# Altium schematic sheet KITT Combined LEDs.SchDoc("KITT Combined LEDs")
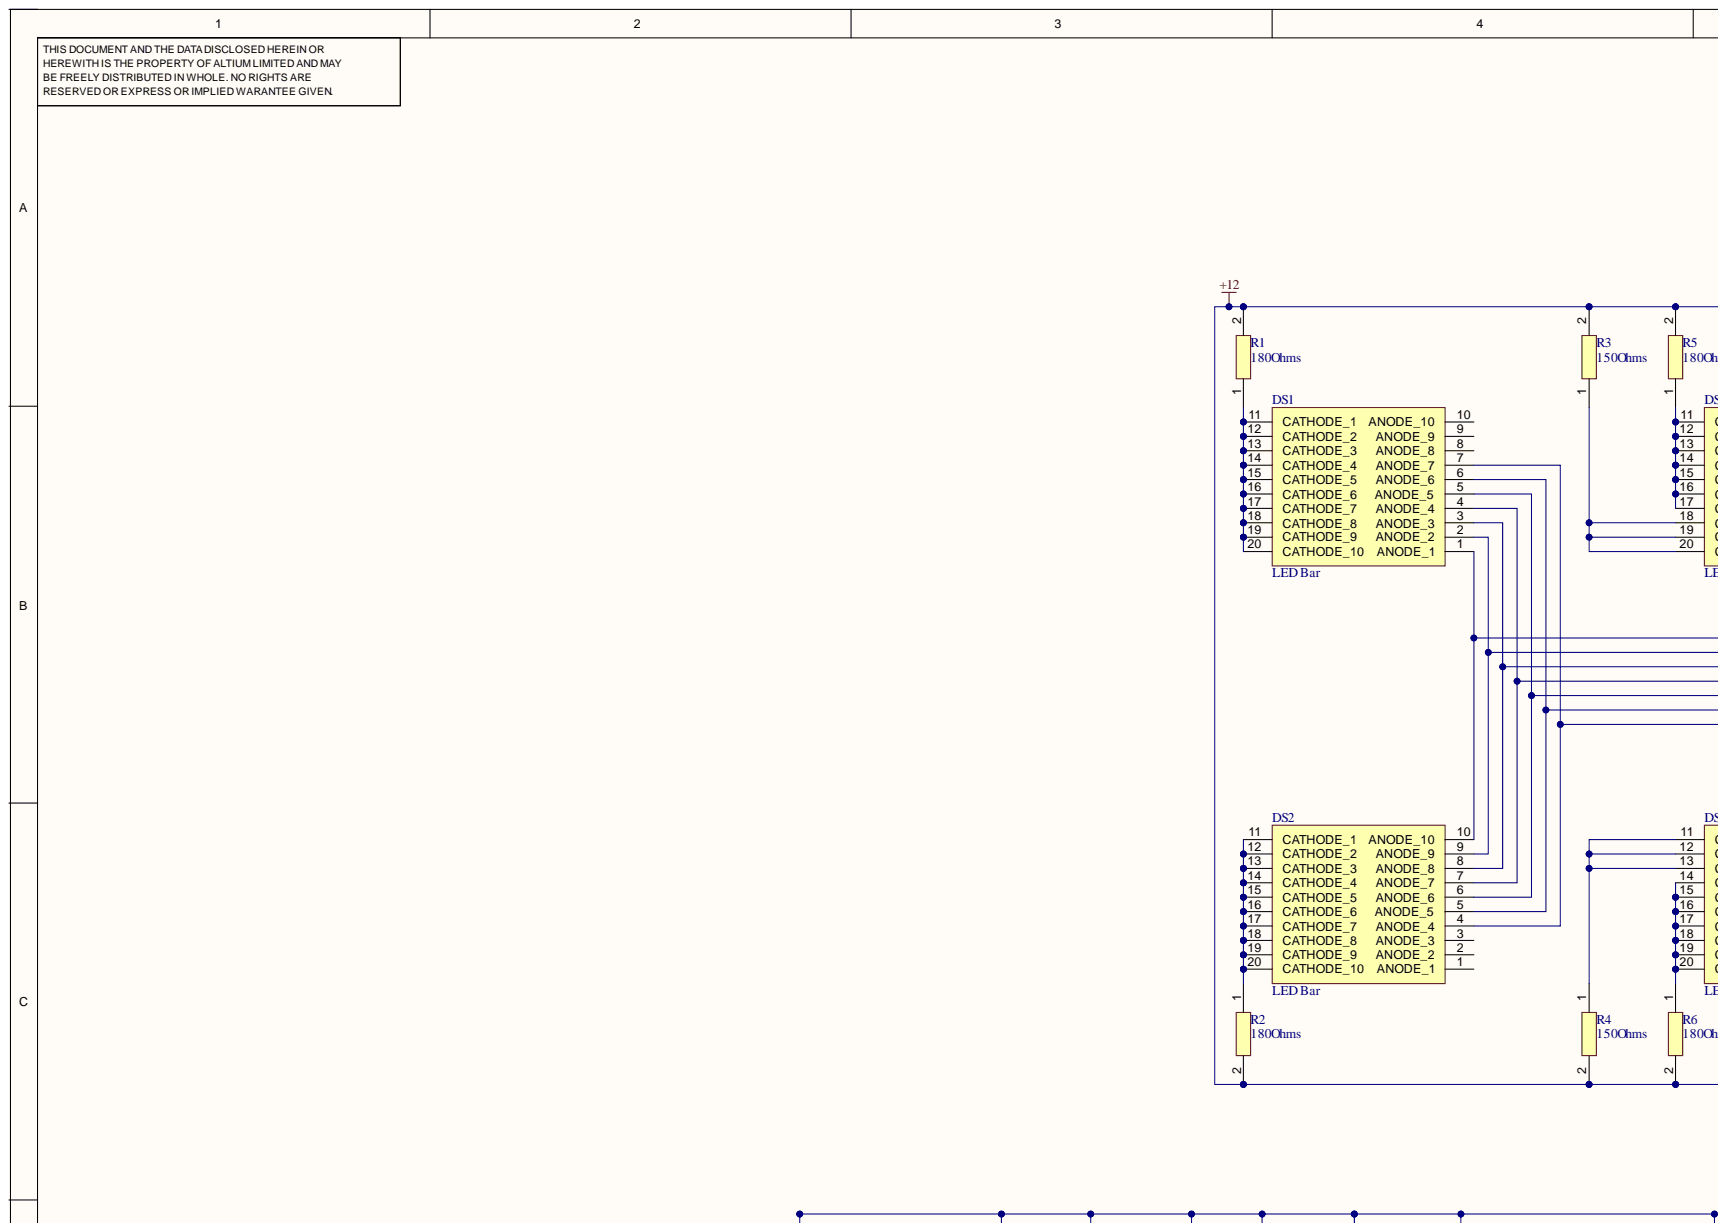
[diagram p0: KITT Combined LEDs.SchDoc, top left]
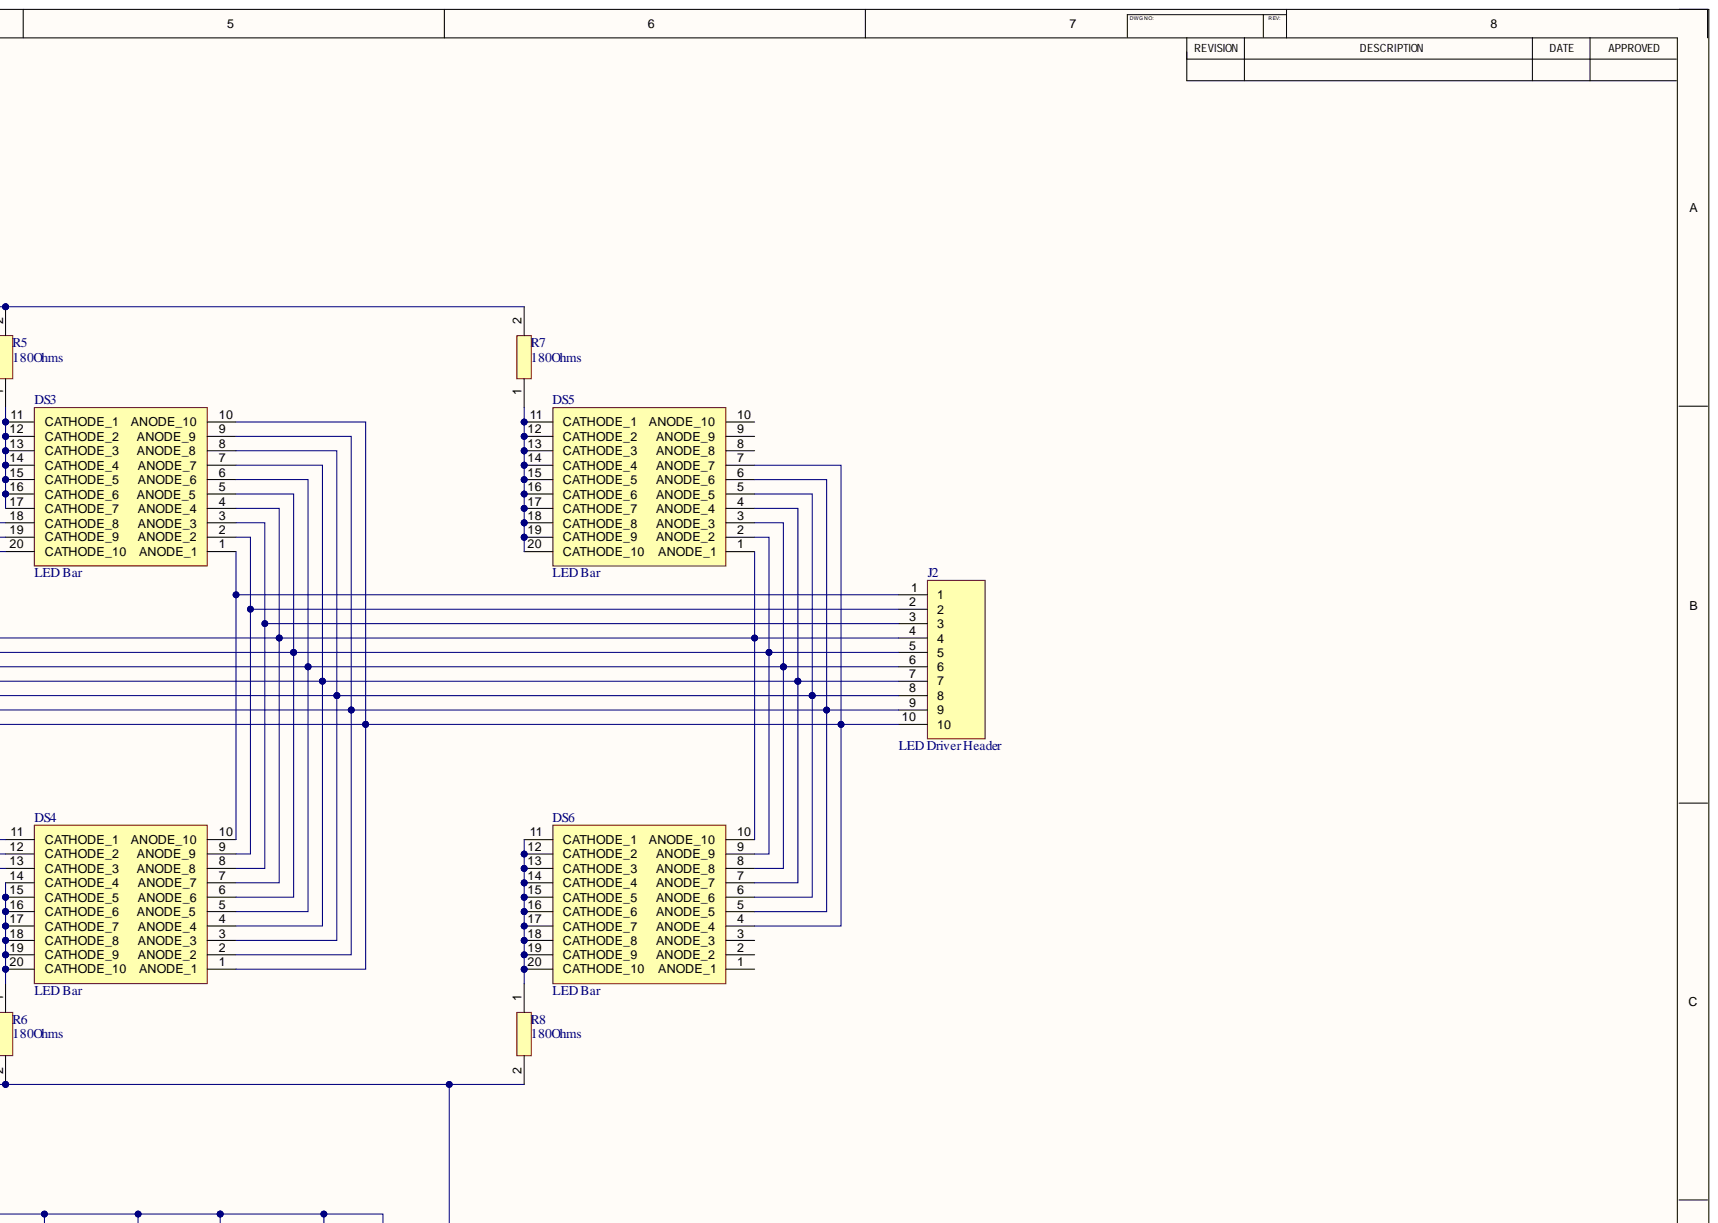
[diagram p1: KITT Combined LEDs.SchDoc, top right]
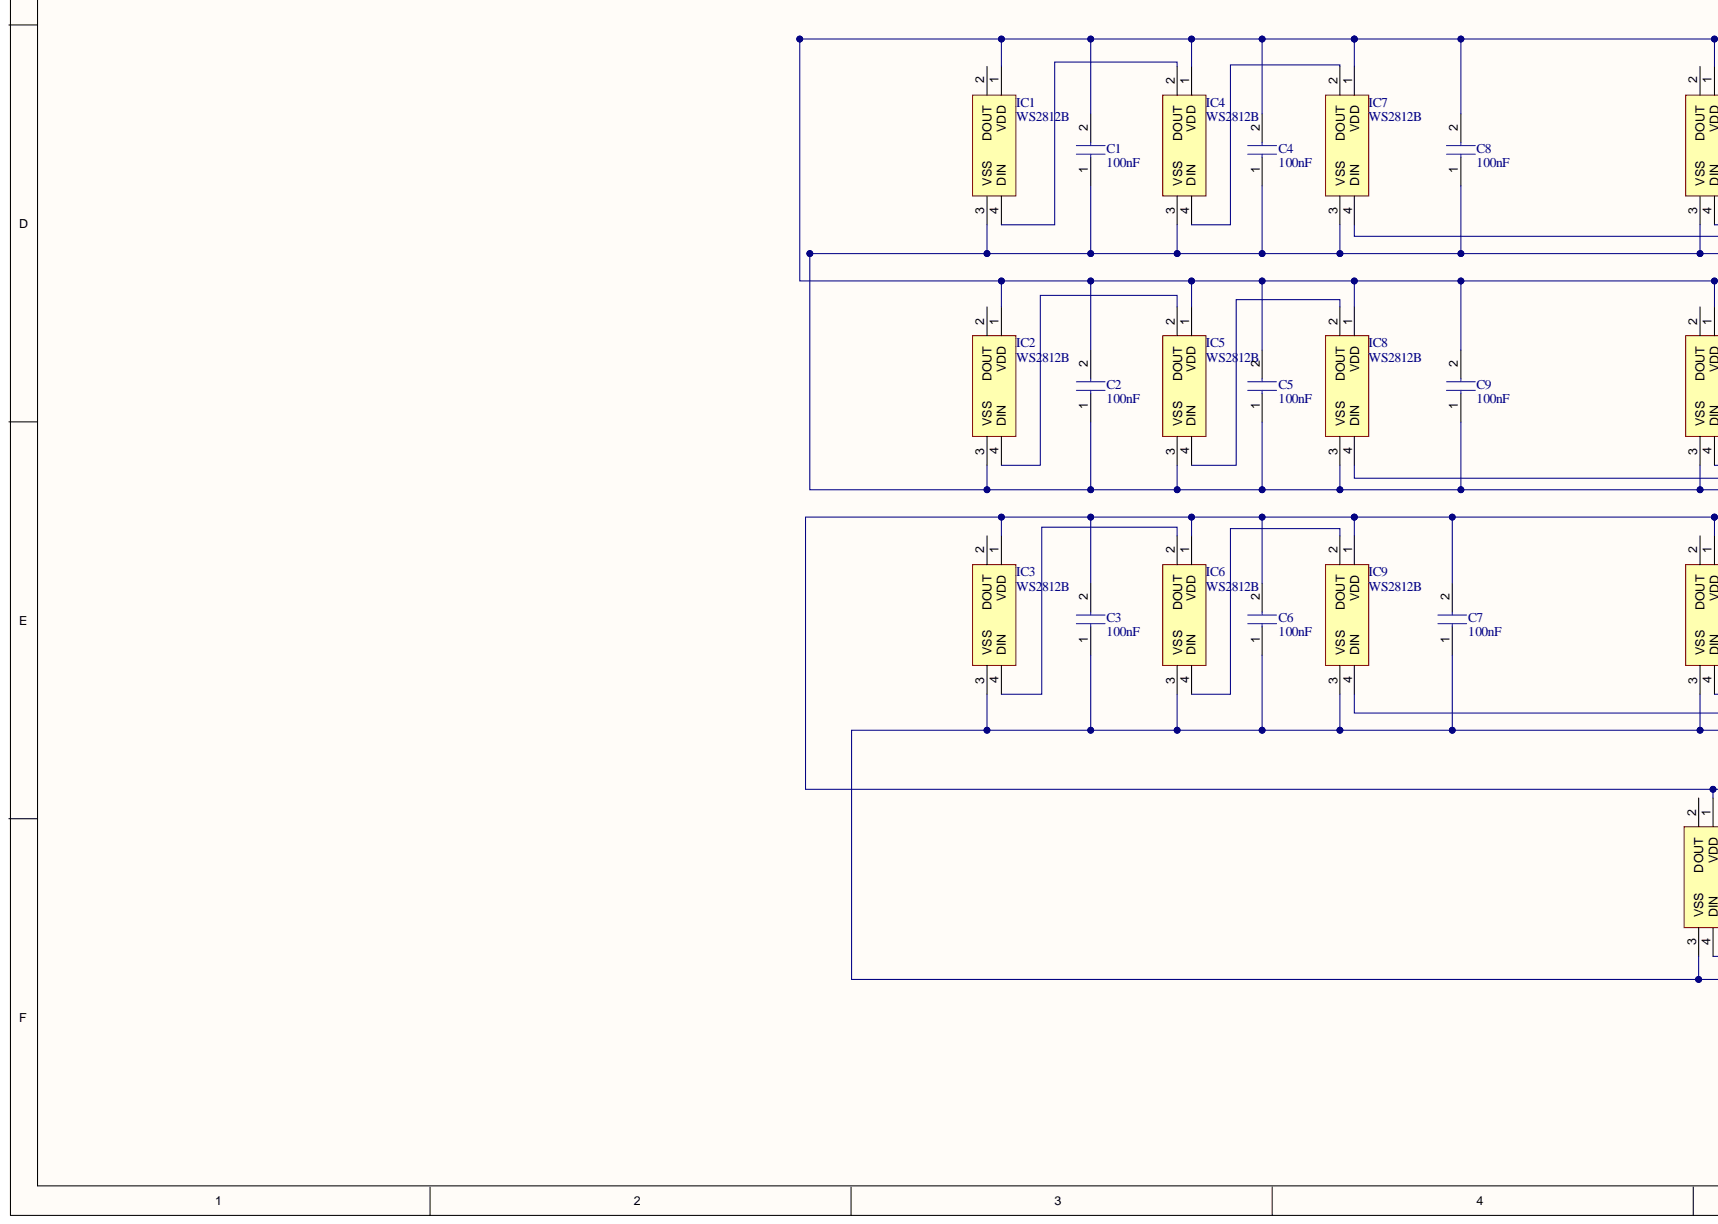
[diagram p2: KITT Combined LEDs.SchDoc, bottom left]
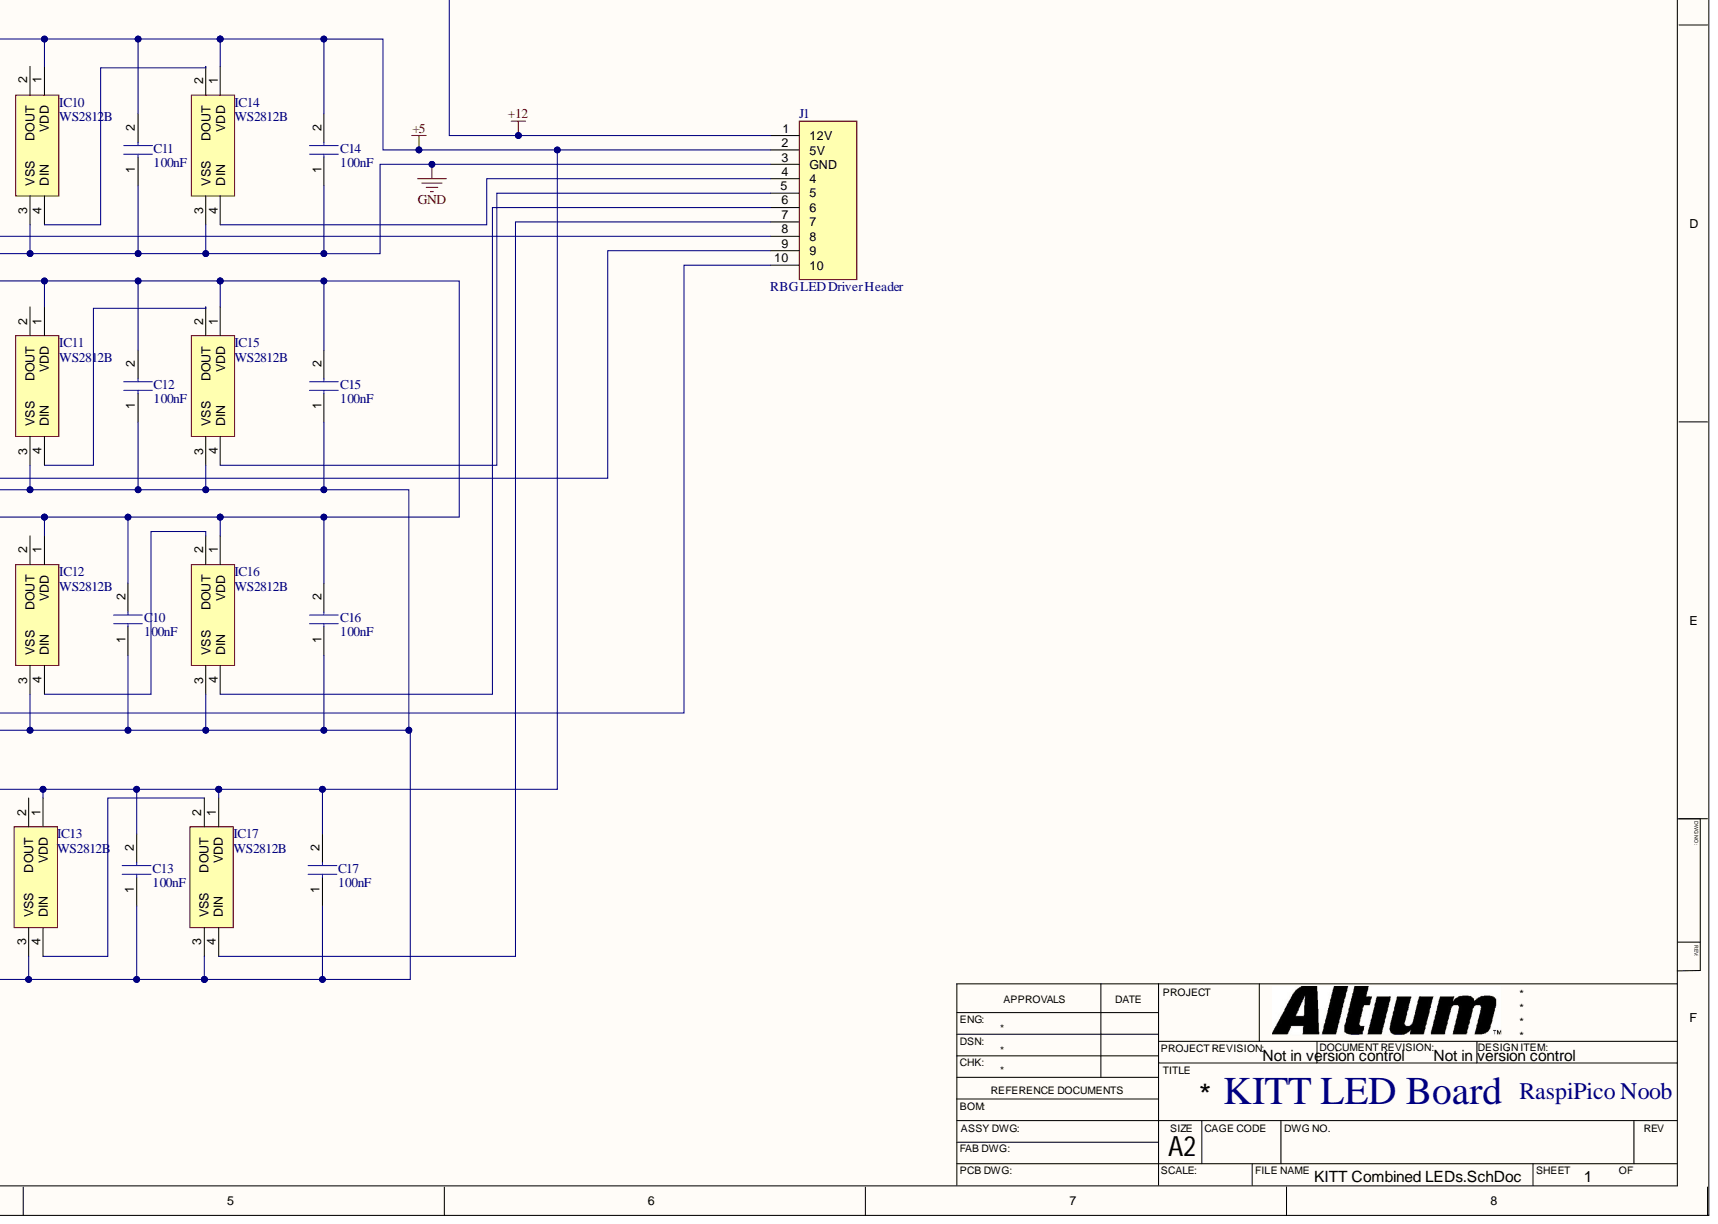
[diagram p3: KITT Combined LEDs.SchDoc, bottom right]

## Parts
C1  100nF  [CAPC2012X95N]
C2  100nF  [CAPC2012X95N]
C3  100nF  [CAPC2012X95N]
C4  100nF  [CAPC2012X95N]
C5  100nF  [CAPC2012X95N]
C6  100nF  [CAPC2012X95N]
C7  100nF  [CAPC2012X95N]
C8  100nF  [CAPC2012X95N]
C9  100nF  [CAPC2012X95N]
C10  100nF  [CAPC2012X95N]
C11  100nF  [CAPC2012X95N]
C12  100nF  [CAPC2012X95N]
C13  100nF  [CAPC2012X95N]
C14  100nF  [CAPC2012X95N]
C15  100nF  [CAPC2012X95N]
C16  100nF  [CAPC2012X95N]
C17  100nF  [CAPC2012X95N]
DS1  LED Bar  [DIP750W50P254L2540H825Q20N]
DS2  LED Bar  [DIP750W50P254L2540H825Q20N]
DS3  LED Bar  [DIP750W50P254L2540H825Q20N]
DS4  LED Bar  [DIP750W50P254L2540H825Q20N]
DS5  LED Bar  [DIP750W50P254L2540H825Q20N]
DS6  LED Bar  [DIP750W50P254L2540H825Q20N]
IC1  WS2812B  [COM16346]
IC2  WS2812B  [COM16346]
IC3  WS2812B  [COM16346]
IC4  WS2812B  [COM16346]
IC5  WS2812B  [COM16346]
IC6  WS2812B  [COM16346]
IC7  WS2812B  [COM16346]
IC8  WS2812B  [COM16346]
IC9  WS2812B  [COM16346]
IC10  WS2812B  [COM16346]
IC11  WS2812B  [COM16346]
IC12  WS2812B  [COM16346]
IC13  WS2812B  [COM16346]
IC14  WS2812B  [COM16346]
IC15  WS2812B  [COM16346]
IC16  WS2812B  [COM16346]
IC17  WS2812B  [COM16346]
J1  RBG LED Driver Header  [HDRV10W50P12X200_1X10_2000X218X525P]
J2  LED Driver Header  [HDRV10W50P12X200_1X10_2000X218X525P]
R1  180Ohms  [RESAD1550W60L630D230]
R2  180Ohms  [RESAD1550W60L630D230]
R3  150Ohms  [RESAD1041W46L381D165]
R4  150Ohms  [RESAD1041W46L381D165]
R5  180Ohms  [RESAD1550W60L630D230]
R6  180Ohms  [RESAD1550W60L630D230]
R7  180Ohms  [RESAD1550W60L630D230]
R8  180Ohms  [RESAD1550W60L630D230]

## Nets
+5: C1.2 C2.2 C3.2 C4.2 C5.2 C6.2 C7.2 C8.2 C9.2 C10.2 C11.2 C12.2 C13.2 C14.2 C15.2 C16.2 C17.2 IC1.1(VDD) IC2.1(VDD) IC3.1(VDD) IC4.1(VDD) IC5.1(VDD) IC6.1(VDD) IC7.1(VDD) IC8.1(VDD) IC9.1(VDD) IC10.1(VDD) IC11.1(VDD) IC12.1(VDD) IC13.1(VDD) IC14.1(VDD) IC15.1(VDD) IC16.1(VDD) IC17.1(VDD) J1.2(5V)
+12: J1.1(12V) R1.2 R2.2 R3.2 R4.2 R5.2 R6.2 R7.2 R8.2
GND: C1.1 C2.1 C3.1 C4.1 C5.1 C6.1 C7.1 C8.1 C9.1 C10.1 C11.1 C12.1 C13.1 C14.1 C15.1 C16.1 C17.1 IC1.3(VSS) IC2.3(VSS) IC3.3(VSS) IC4.3(VSS) IC5.3(VSS) IC6.3(VSS) IC7.3(VSS) IC8.3(VSS) IC9.3(VSS) IC10.3(VSS) IC11.3(VSS) IC12.3(VSS) IC13.3(VSS) IC14.3(VSS) IC15.3(VSS) IC16.3(VSS) IC17.3(VSS) J1.3(GND)
NetDS1_1: DS1.1(ANODE_1) DS2.10(ANODE_10) DS3.4(ANODE_4) DS4.7(ANODE_7) DS5.1(ANODE_1) DS6.10(ANODE_10) J2.4
NetDS1_2: DS1.2(ANODE_2) DS2.9(ANODE_9) DS3.5(ANODE_5) DS4.6(ANODE_6) DS5.2(ANODE_2) DS6.9(ANODE_9) J2.5
NetDS1_3: DS1.3(ANODE_3) DS2.8(ANODE_8) DS3.6(ANODE_6) DS4.5(ANODE_5) DS5.3(ANODE_3) DS6.8(ANODE_8) J2.6
NetDS1_4: DS1.4(ANODE_4) DS2.7(ANODE_7) DS3.7(ANODE_7) DS4.4(ANODE_4) DS5.4(ANODE_4) DS6.7(ANODE_7) J2.7
NetDS1_5: DS1.5(ANODE_5) DS2.6(ANODE_6) DS3.8(ANODE_8) DS4.3(ANODE_3) DS5.5(ANODE_5) DS6.6(ANODE_6) J2.8
NetDS1_6: DS1.6(ANODE_6) DS2.5(ANODE_5) DS3.9(ANODE_9) DS4.2(ANODE_2) DS5.6(ANODE_6) DS6.5(ANODE_5) J2.9
NetDS1_7: DS1.7(ANODE_7) DS2.4(ANODE_4) DS3.10(ANODE_10) DS4.1(ANODE_1) DS5.7(ANODE_7) DS6.4(ANODE_4) J2.10
NetDS1_11: DS1.11(CATHODE_1) DS1.12(CATHODE_2) DS1.13(CATHODE_3) DS1.14(CATHODE_4) DS1.15(CATHODE_5) DS1.16(CATHODE_6) DS1.17(CATHODE_7) DS1.18(CATHODE_8) DS1.19(CATHODE_9) DS1.20(CATHODE_10) R1.1
NetDS2_11: DS2.11(CATHODE_1) DS2.12(CATHODE_2) DS2.13(CATHODE_3) DS2.14(CATHODE_4) DS2.15(CATHODE_5) DS2.16(CATHODE_6) DS2.17(CATHODE_7) DS2.18(CATHODE_8) DS2.19(CATHODE_9) DS2.20(CATHODE_10) R2.1
NetDS3_1: DS3.1(ANODE_1) DS4.10(ANODE_10) J2.1
NetDS3_2: DS3.2(ANODE_2) DS4.9(ANODE_9) J2.2
NetDS3_3: DS3.3(ANODE_3) DS4.8(ANODE_8) J2.3
NetDS3_11: DS3.11(CATHODE_1) DS3.12(CATHODE_2) DS3.13(CATHODE_3) DS3.14(CATHODE_4) DS3.15(CATHODE_5) DS3.16(CATHODE_6) DS3.17(CATHODE_7) R5.1
NetDS3_18: DS3.18(CATHODE_8) DS3.19(CATHODE_9) DS3.20(CATHODE_10) R3.1
NetDS4_11: DS4.11(CATHODE_1) DS4.12(CATHODE_2) DS4.13(CATHODE_3) R4.1
NetDS4_14: DS4.14(CATHODE_4) DS4.15(CATHODE_5) DS4.16(CATHODE_6) DS4.17(CATHODE_7) DS4.18(CATHODE_8) DS4.19(CATHODE_9) DS4.20(CATHODE_10) R6.1
NetDS5_11: DS5.11(CATHODE_1) DS5.12(CATHODE_2) DS5.13(CATHODE_3) DS5.14(CATHODE_4) DS5.15(CATHODE_5) DS5.16(CATHODE_6) DS5.17(CATHODE_7) DS5.18(CATHODE_8) DS5.19(CATHODE_9) DS5.20(CATHODE_10) R7.1
NetDS6_11: DS6.11(CATHODE_1) DS6.12(CATHODE_2) DS6.13(CATHODE_3) DS6.14(CATHODE_4) DS6.15(CATHODE_5) DS6.16(CATHODE_6) DS6.17(CATHODE_7) DS6.18(CATHODE_8) DS6.19(CATHODE_9) DS6.20(CATHODE_10) R8.1
NetIC1_4: IC1.4(DIN) IC4.2(DOUT)
NetIC2_4: IC2.4(DIN) IC5.2(DOUT)
NetIC3_4: IC3.4(DIN) IC6.2(DOUT)
NetIC4_4: IC4.4(DIN) IC7.2(DOUT)
NetIC5_4: IC5.4(DIN) IC8.2(DOUT)
NetIC6_4: IC6.4(DIN) IC9.2(DOUT)
NetIC7_4: IC7.4(DIN) J1.8
NetIC8_4: IC8.4(DIN) J1.9
NetIC9_4: IC9.4(DIN) J1.10
NetIC10_4: IC10.4(DIN) IC14.2(DOUT)
NetIC11_4: IC11.4(DIN) IC15.2(DOUT)
NetIC12_4: IC12.4(DIN) IC16.2(DOUT)
NetIC13_4: IC13.4(DIN) IC17.2(DOUT)
NetIC14_4: IC14.4(DIN) J1.4
NetIC15_4: IC15.4(DIN) J1.5
NetIC16_4: IC16.4(DIN) J1.6
NetIC17_4: IC17.4(DIN) J1.7

Not connected: DS1 8(ANODE_8) 9(ANODE_9) 10(ANODE_10); DS2 1(ANODE_1) 2(ANODE_2) 3(ANODE_3); DS5 8(ANODE_8) 9(ANODE_9) 10(ANODE_10); DS6 1(ANODE_1) 2(ANODE_2) 3(ANODE_3); IC1 2(DOUT); IC2 2(DOUT); IC3 2(DOUT); IC10 2(DOUT); IC11 2(DOUT); IC12 2(DOUT); IC13 2(DOUT)

## Library data of the parts
C1-C17 = AC0805KKX7R9BB104: Capacitor; Manufacturer_Name: KEMET; Component Kind: Standard; Datasheet Link: https://www.digikey.in/en/products/detail/yageo/AC0805KKX7R9BB104/11489918; Height: 0.95mm; Manufacturer_Part_Number: AC0805KKX7R9BB104; Mouser Part Number: 603-AC0805KKX7R9BB10; Mouser Price/Stock: https://www.mouser.co.uk/ProductDetail/Yageo/AC0805KKX7R9BB104?qs=YCa%2FAAYMW02bfg1SV5KQOA%3D%3D
DS1-DS6 = DC10SRWA: Display; Manufacturer_Name: Kingbright; Component Kind: Standard; Datasheet Link: https://altium.componentsearchengine.com/Datasheets/1/DC10SRWA.pdf; Height: 8.25mm; Manufacturer_Part_Number: DC10SRWA; Mouser Part Number: 604-DC10SRWA; Mouser Price/Stock: https://www.mouser.co.uk/ProductDetail/Kingbright/DC10SRWA?qs=SxaZfIVsCL3oiC6rI9sPSQ%3D%3D
IC1-IC17 = COM-16346: Integrated Circuit; Manufacturer_Name: SparkFun; Arrow Part Number: COM-16346; Arrow Price/Stock: https://www.arrow.com/en/products/com-16346/sparkfun-electronics?region=nac; Component Kind: Standard; Datasheet Link: http://cdn.sparkfun.com/datasheets/BreakoutBoards/WS2812B.pdf; Height: 2.25mm; Manufacturer_Part_Number: COM-16346; Mouser Part Number: 474-COM-16346; Mouser Price/Stock: https://www.mouser.co.uk/ProductDetail/SparkFun/COM-16346?qs=OlC7AqGiEDnspdV1Dchx7w%3D%3D
J1 J2 = M22-2011005: Connector; Manufacturer_Name: Harwin; Component Kind: Standard; Datasheet Link: https://componentsearchengine.com/Datasheets/3/M22-2011005.pdf; Height: 5.25mm; Manufacturer_Part_Number: M22-2011005; Mouser Part Number: 855-M22-2011005; Mouser Price/Stock: https://www.mouser.com/Search/Refine.aspx?Keyword=855-M22-2011005
R1 R2 R5-R8 = MF1_4DC1800F: Resistor; Manufacturer_Name: KOA Speer; Manufacturer_Part_Number: MF1/4DC1800F; Component Kind: Standard; Datasheet Link: http://www.koaspeer.com/catimages/Products/MF-MFS-RK/MF-MFS-RK.pdf
R3 R4 = CMF50150R00FHEB: Resistor; Manufacturer_Name: Vishay; Component Kind: Standard; Datasheet Link: https://www.vishay.com/docs/31018/cmfind.pdf; Manufacturer_Part_Number: CMF50150R00FHEB; Mouser Part Number: 71-CMF50150R00FHEB; Mouser Price/Stock: https://www.mouser.com/Search/Refine.aspx?Keyword=71-CMF50150R00FHEB
